# Altium schematic sheet CAN.SchDoc(CAN)
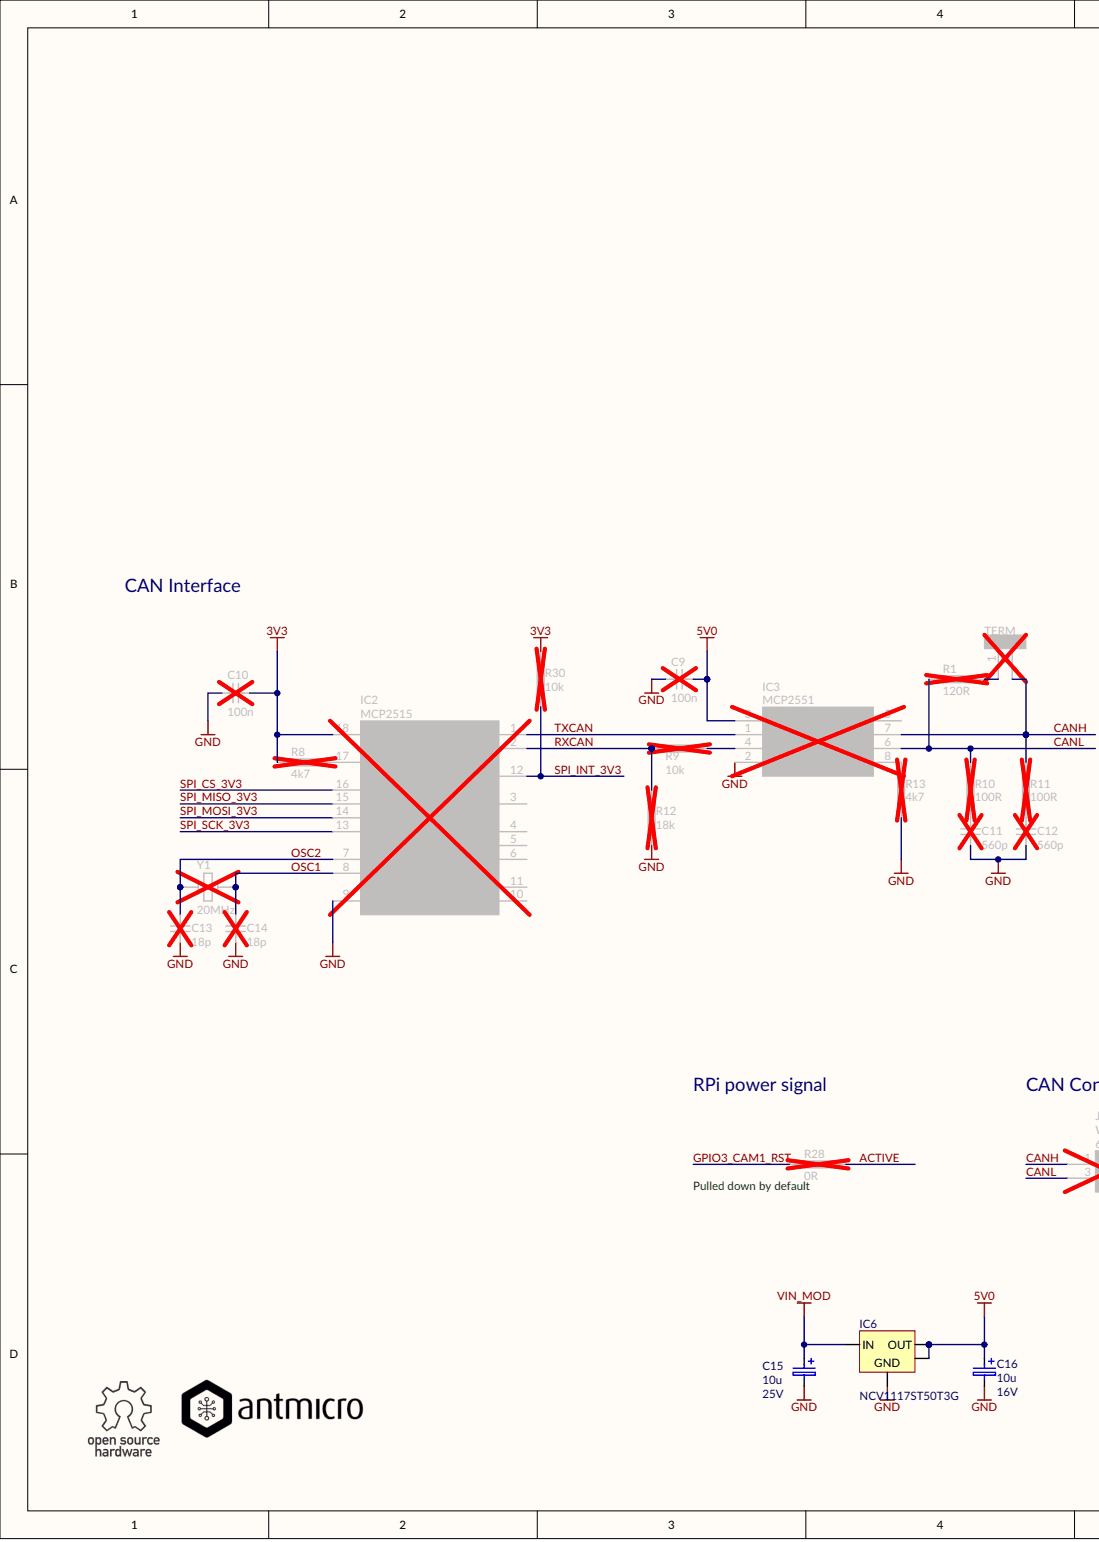
[diagram p0: CAN.SchDoc, left]
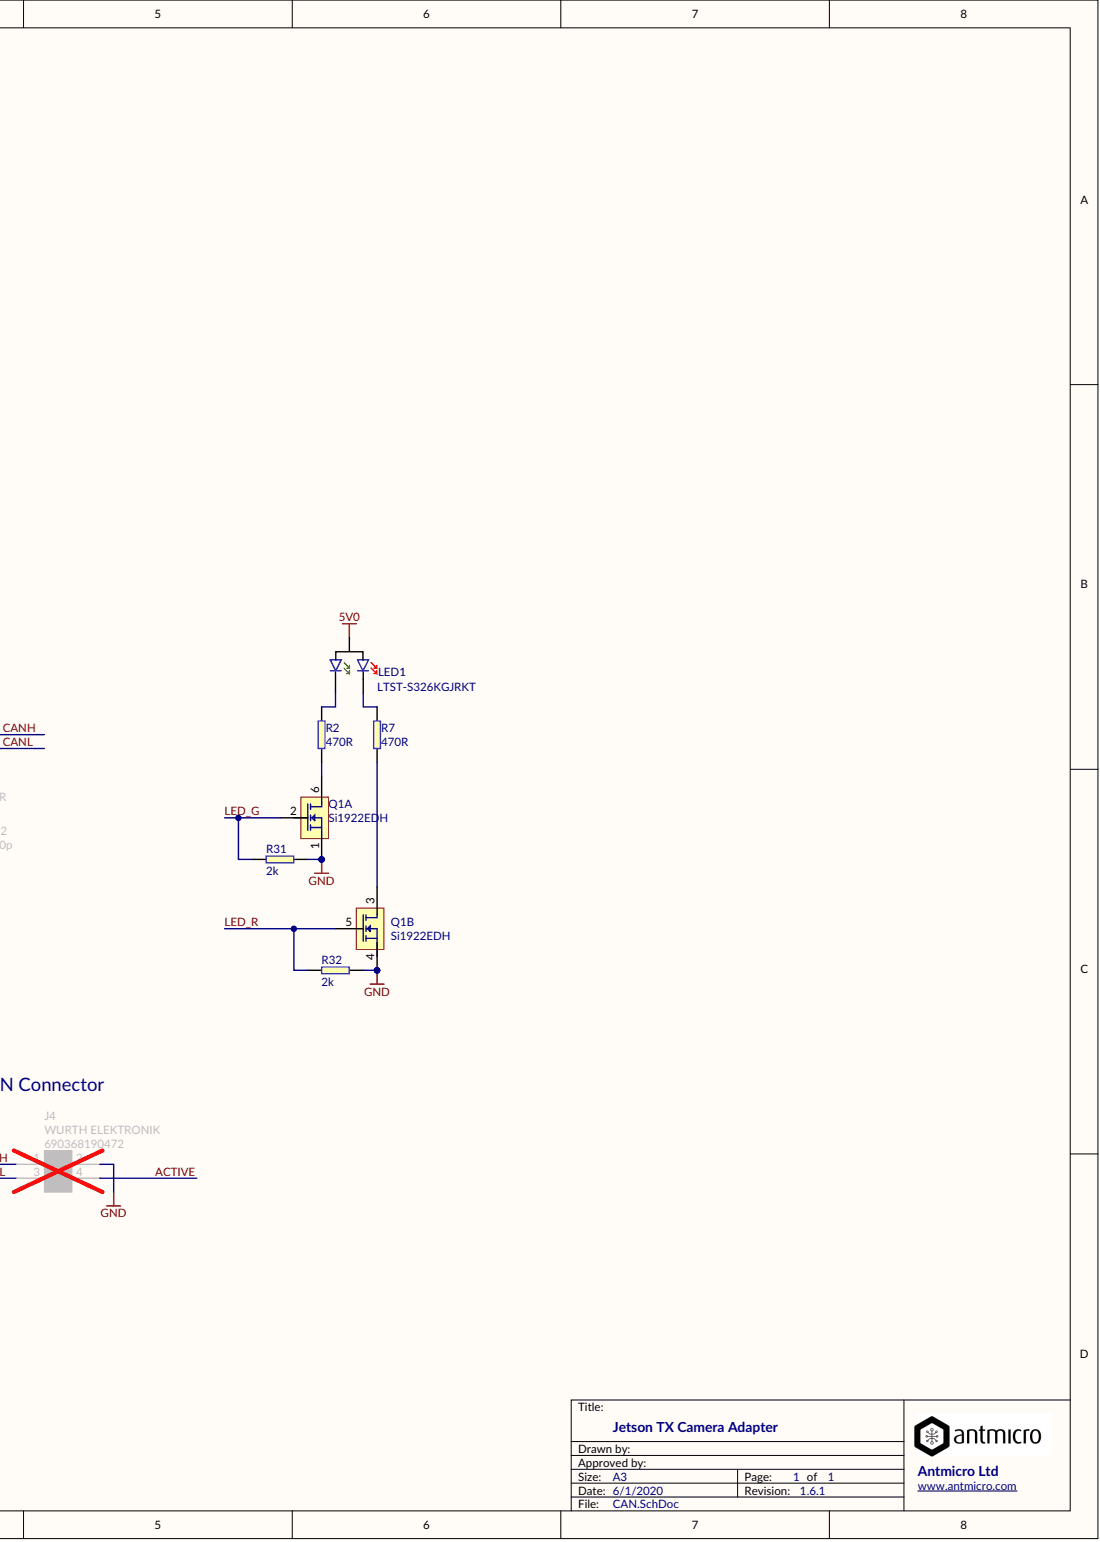
[diagram p1: CAN.SchDoc, right]

## Parts
C9  100n
C10  100n
C11  560p
C12  560p
C13  18p
C14  18p
C15  value 10u  Voltage: 25V  (hidden comment: CPol)
C16  Voltage: 16V  (hidden comment: 10u)
IC2  MCP2515
IC3  MCP2551
IC6  value NCV1117ST50T3G  (hidden comment: xx1117)
J4  Manufacturer: WURTH ELEKTRONIK  Part Symbol: 690368190472  (hidden comment: WE_690368190472)
LED1  Part Symbol: LTST-S326KGJRKT  (hidden comment: LED-LiteON-LTST-S326KGJRKT)
Q1  Si1922EDH  (parts A,B)
R1  120R
R2  470R
R7  470R
R8  4k7
R9  10k
R10  100R
R11  100R
R12  18k
R13  4k7
R28  0R
R30  10k
R31  2k
R32  2k
TERM  HEADER_1X2_male  (value not drawn)
Y1  20MHz

## Nets
3V3: C10.1 IC2.18(VDD) R8.2 R30.2
5V0: C9.1 C16.1 IC3.3(VDD) IC6.2(OUT) IC6.4(GND) LED1.A
GND: C9.2 C10.2 C11.1 C12.1 C13.1 C14.1 C15.2 C16.2 IC2.9(VSS) IC3.2(VSS) IC6.1 J4.2 Q1.1 Q1.4 R12.1 R13.1 R31.2 R32.2
VIN_MOD: C15.1 IC6.3(IN)
ACTIVE: J4.4 R28.2
CANH: IC3.7(CANH) J4.1 R11.2 TERM.2
CANL: IC3.6(CANL) J4.3 R1.1 R10.2
GPIO3_CAM1_RST: R28.1
LED_G: Q1.2 R31.1
LED_R: Q1.5 R32.1
OSC1: C14.2 IC2.8(OSC1) Y1.1
OSC2: C13.2 IC2.7(OSC2) Y1.2
RXCAN: IC2.2(RXCAN) R9.1 R12.2
SPI_CS_3V3: IC2.16(CS)
SPI_INT_3V3: IC2.12(INT) R30.1
SPI_MISO_3V3: IC2.15(SO)
SPI_MOSI_3V3: IC2.14(SI)
SPI_SCK_3V3: IC2.13(SCK)
TXCAN: IC2.1(TXCAN) IC3.1(TXD)
NetC11_2: C11.2 R10.1
NetC12_2: C12.2 R11.1
NetIC2_17: IC2.17(RESET) R8.1
NetIC3_4: IC3.4(RXD) R9.2
NetIC3_8: IC3.8(RS) R13.2
NetLED1_C1: LED1.C1 R7.2
NetLED1_C2: LED1.C2 R2.2
NetQ1_3: Q1.3 R7.1
NetQ1_6: Q1.6 R2.1
NetR1_2: R1.2 TERM.1

Not connected: IC2 3(CLKOUT/SOF) 4(TX0RTS) 5(TX1RTS) 6(TX2RTS) 10(RX1BF) 11(RX0BF); IC3 5(VREF)

## Library data of the parts
C9 C10 = (no symbol name): Part Number: C_100n_0402; Manufacturer: MULTICOMP; Part Symbol: MC0402X104M100CT; Value: 100n; Supplier 1: Farnell; Supplier Part Number 1: 2309011
C11 C12 = (no symbol name): Part Number: C_560p_0603; Manufacturer: MULTICOMP; Part Symbol: MC0603N561J500CT; Value: 560p; Supplier 1: Farnell; Supplier Part Number 1: 1759082
C13 C14 = (no symbol name): Part Number: C_18p_0402; Manufacturer: KEMET; Part Symbol: C0402C180J5GACAUTO; Value: 18p; Supplier 1: Farnell; Supplier Part Number 1: 2070379
C15 = (no symbol name): Part Number: C_10u_KEMET_C; Manufacturer: KEMET; Part Symbol: T491C106K025AT; Supplier 1: Farnell; Supplier Part Number 1: 1457463
C16 = (no symbol name): Part Number: C_10u_KEMET_A; Manufacturer: VISHAY; Part Symbol: 293D106X9016A2TE3; Value: 10u; Supplier 1: Farnell; Supplier Part Number 1: 1754182
IC2 = (no symbol name): Part Number: MCP2515-I/SO; Manufacturer: MICROCHIP; Supplier 1: Farnell; Supplier Part Number 1: 1292239; Value: MCP2515; Part Symbol: MCP2515-I/SO
IC3 = (no symbol name): Part Number: MCP2551-I/SN; Manufacturer: MICROCHIP; Supplier 1: Farnell; Supplier Part Number 1: 9758569; Value: MCP2551; Part Symbol: MCP2551-I/SN
IC6 = (no symbol name): Manufacturer: ON SEMICONDUCTOR; Part Number: NCV1117ST50T3G; Supplier 1: Farnell; Supplier Part Number 1: 2534280; Part Symbol: NCV1117ST50T3G
LED1 = (no symbol name): Manufacturer: Lite-On; Part Number: LED-LiteON-LTST-S326KGJRKT; Value: LED-LiteON-LTST-S326KGJRKT; Supplier 1: Mouser; Supplier Part Number 1: 859-LTST-S326KGJRKT
Q1 = (no symbol name): Manufacturer: Vishay; Part Number: Si1922EDH; Value: Si1922EDH; Supplier 1: Farnell; Supplier Part Number 1: 2056714; Part Symbol: Si1922EDH
R1 = (no symbol name): Part Number: R_120R_0603; Manufacturer: VISHAY; Part Symbol: CRCW0603120RFKEA; Tolerance: 1%; Value: 120R; Supplier 1: Farnell; Supplier Part Number 1: 1652832
R2 R7 = (no symbol name): Part Number: R_470R_0603; Manufacturer: BOURNS; Part Symbol: CR0603-JW-471GLF; Value: 470R; Supplier 1: Farnell; Supplier Part Number 1: 2008354
R8 R13 = (no symbol name): Part Number: R_4k7_0603; Manufacturer: BOURNS; Part Symbol: CR0603-FX-4701ELF; Value: 4k7; Supplier 1: Farnell; Supplier Part Number 1: 2008340
R9 R30 = (no symbol name): Part Number: R_10k_0603; Manufacturer: BOURNS; Part Symbol: CR0603-JW-103ELF; Value: 10k; Supplier 1: Farnell; Supplier Part Number 1: 2008360
R10 R11 = (no symbol name): Part Number: R_100R_0603; Manufacturer: MULTICOMP; Part Symbol: MC0063W06035100R; Tolerance: 5%; Value: 100R; Supplier 1: Farnell; Supplier Part Number 1: 9331689
R12 = (no symbol name): Part Number: R_18k_0603; Manufacturer: MULTICOMP; Part Symbol: MC0063W0603118K; Tolerance: 1%; Value: 18k; Supplier 1: Farnell; Supplier Part Number 1: 9330720
R28 = (no symbol name): Part Number: R_0R_0603; Manufacturer: BOURNS; Part Symbol: CR0603-J/-000ELF; Tolerance: 5%; Value: 0R; Supplier 1: Farnell; Supplier Part Number 1: 2008343
R31 R32 = (no symbol name): Part Number: R_2k_0603; Manufacturer: MULTICOMP; Part Symbol: MCMR06X2001FTL; Value: 2k; Supplier 1: Farnell; Supplier Part Number 1: 2073418
Y1 = (no symbol name): Part Number: CRYSTAL_20MHz_SMD_TXC; Manufacturer: TXC; Part Symbol: 7A-20.000MAAJ-T; Value: 20MHz; Supplier 1: Farnell; Supplier Part Number 1: 1841950
J4 TERM = (no symbol name)
  J4: Part Number: WE_690368190472; Value: WE_690368190472
  TERM: Part Number: HEADER_1X2_male
